# Altium schematic sheet MBoard_Connectors.SchDoc
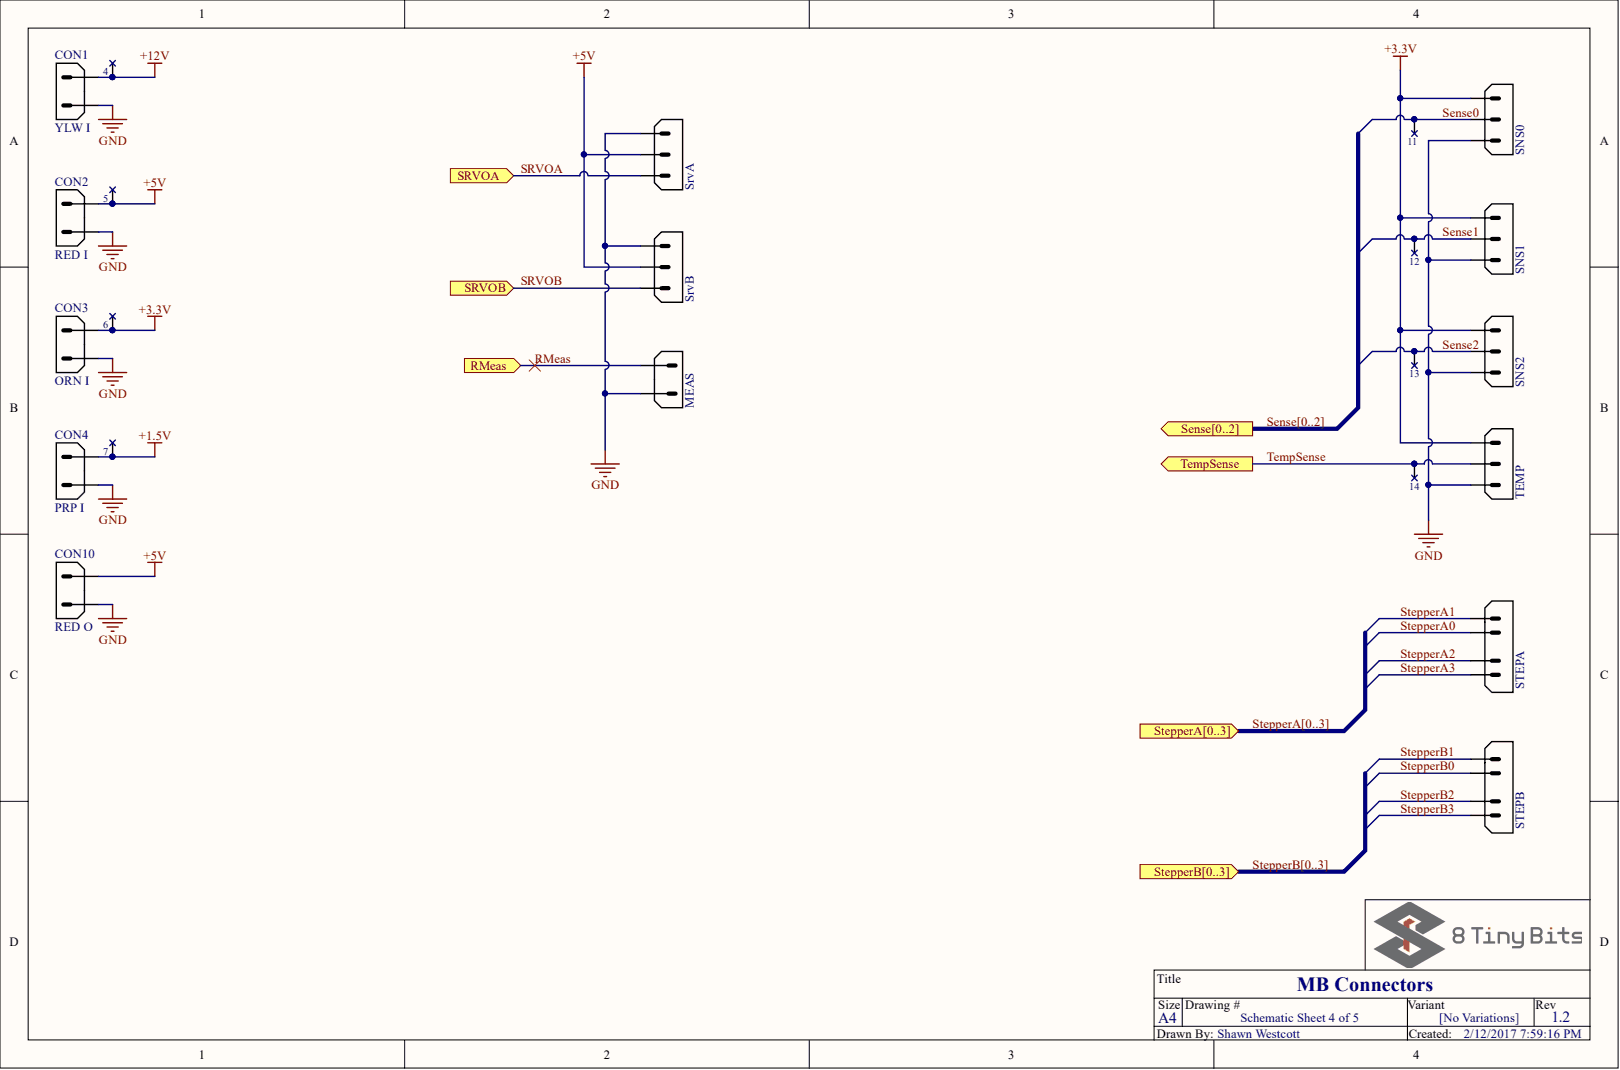
[diagram p0: MBoard_Connectors.SchDoc]

## Parts
4  TEST POINT  [TP_PLATING]  (value not drawn)
5  TEST POINT  [TP_PLATING]  (value not drawn)
6  TEST POINT  [TP_PLATING]  (value not drawn)
7  TEST POINT  [TP_PLATING]  (value not drawn)
11  TEST POINT  [TP_PLATING]  (value not drawn)
12  TEST POINT  [TP_PLATING]  (value not drawn)
13  TEST POINT  [TP_PLATING]  (value not drawn)
14  TEST POINT  [TP_PLATING]  (value not drawn)
CON1  Color: YLW I  [CP-6.5_2PIN]  (hidden comment: CP-6.5 Connectors)
CON2  Color: RED I  [CP-6.5_2PIN]  (hidden comment: CP-6.5 Connectors)
CON3  Color: ORN I  [CP-6.5_2PIN]  (hidden comment: CP-6.5 Connectors)
CON4  Color: PRP I  [CP-6.5_2PIN]  (hidden comment: CP-6.5 Connectors)
CON10  Color: RED O  [CP-6.5_2PIN]  (hidden comment: CP-6.5 Connectors)
MEAS  HEADER, 2 POS  [HEADER, 2 POS]  (value not drawn)
SNS0  3 POS HEADER  [HEADER, 3 POS]  (value not drawn)
SNS1  3 POS HEADER  [HEADER, 3 POS]  (value not drawn)
SNS2  3 POS HEADER  [HEADER, 3 POS]  (value not drawn)
SrvA  3 POS HEADER  [HEADER, 3 POS]  (value not drawn)
SrvB  3 POS HEADER  [HEADER, 3 POS]  (value not drawn)
STEPA  4 POS HEADER  [HEADER, 4 POS]  (value not drawn)
STEPB  4 POS HEADER  [HEADER, 4 POS]  (value not drawn)
TEMP  3 POS HEADER  [HEADER, 3 POS]  (value not drawn)

## Ports
RMEAS, SENSE0, SENSE1, SENSE2, SENSE[0..2], SRVOA, SRVOB, STEPPERA0, STEPPERA1, STEPPERA2, STEPPERA3, STEPPERA[0..3], STEPPERB0, STEPPERB1, STEPPERB2, STEPPERB3, STEPPERB[0..3], TEMPSENSE

## Nets
+1.5V: 7.0 CON4.2
+3.3V: 6.0 CON3.2 SNS0.1 SNS1.1 SNS2.1 TEMP.1
+5V: 5.0 CON2.2 CON10.2 SrvA.2 SrvB.2
+12V: 4.0 CON1.2
GND: CON1.1 CON2.1 CON3.1 CON4.1 CON10.1 MEAS.2 SNS0.3 SNS1.3 SNS2.3 SrvA.1 SrvB.1 TEMP.3
RMEAS: MEAS.1 ; port RMEAS
SENSE0: 11.0 SNS0.2 ; port SENSE[0..2]  [also labelled SENSE]
SENSE1: 12.0 SNS1.2 ; port SENSE[0..2]  [also labelled SENSE]
SENSE2: 13.0 SNS2.2 ; port SENSE[0..2]  [also labelled SENSE]
SRVOA: SrvA.3 ; port SRVOA
SRVOB: SrvB.3 ; port SRVOB
STEPPERA0: STEPA.2 ; port STEPPERA[0..3]  [also labelled STEPPERA]
STEPPERA1: STEPA.1 ; port STEPPERA[0..3]  [also labelled STEPPERA]
STEPPERA2: STEPA.3 ; port STEPPERA[0..3]  [also labelled STEPPERA]
STEPPERA3: STEPA.4 ; port STEPPERA[0..3]  [also labelled STEPPERA]
STEPPERB0: STEPB.2 ; port STEPPERB[0..3]  [also labelled STEPPERB]
STEPPERB1: STEPB.1 ; port STEPPERB[0..3]  [also labelled STEPPERB]
STEPPERB2: STEPB.3 ; port STEPPERB[0..3]  [also labelled STEPPERB]
STEPPERB3: STEPB.4 ; port STEPPERB[0..3]  [also labelled STEPPERB]
TEMPSENSE: 14.0 TEMP.2 ; port TEMPSENSE

## Library data of the parts
4-7 11-14 = TP: GEN TEST POINT; Component Kind: Standard; Library Name: Custom_8TB.SchLib
CON1-CON4 CON10 = CP-6.5 Connectors: 2 Positions Header, Shrouded Connector 0.256" (6.50mm) Through Hole Tin; Component Kind: Standard; Library Name: Custom_8TB.SchLib
MEAS = HEADER, 2 POS: CONN HEADER 2POS .100 STR 15AU; Color: RTEST; Component Kind: Standard; Library Name: Custom_8TB.SchLib
SNS0 = HEADER, 3 POS: CONN HEADER 3POS .100 STR 15AU; Connection: HE Sense0; Component Kind: Standard; Library Name: Custom_8TB.SchLib
SNS1 = HEADER, 3 POS: CONN HEADER 3POS .100 STR 15AU; Connection: HE Sense 1; Component Kind: Standard; Library Name: Custom_8TB.SchLib
SNS2 = HEADER, 3 POS: CONN HEADER 3POS .100 STR 15AU; Connection: HE Sense 2; Component Kind: Standard; Library Name: Custom_8TB.SchLib
SrvA = HEADER, 3 POS: CONN HEADER 3POS .100 STR 15AU; Connection: SRVO A; Component Kind: Standard; Library Name: Custom_8TB.SchLib
SrvB = HEADER, 3 POS: CONN HEADER 3POS .100 STR 15AU; Connection: SRVO B; Component Kind: Standard; Library Name: Custom_8TB.SchLib
STEPA = HEADER, 4 POS: CONN HEADER 4POS .100 STR 15AU; Connection: StepA; Component Kind: Standard; Library Name: Custom_8TB.SchLib
STEPB = HEADER, 4 POS: CONN HEADER 4POS .100 STR 15AU; Connection: StepB; Component Kind: Standard; Library Name: Custom_8TB.SchLib
TEMP = HEADER, 3 POS: CONN HEADER 3POS .100 STR 15AU; Connection: Temp Sense 0; Component Kind: Standard; Library Name: Custom_8TB.SchLib
